# Altium schematic sheet CW313 Power Supplies.SchDoc
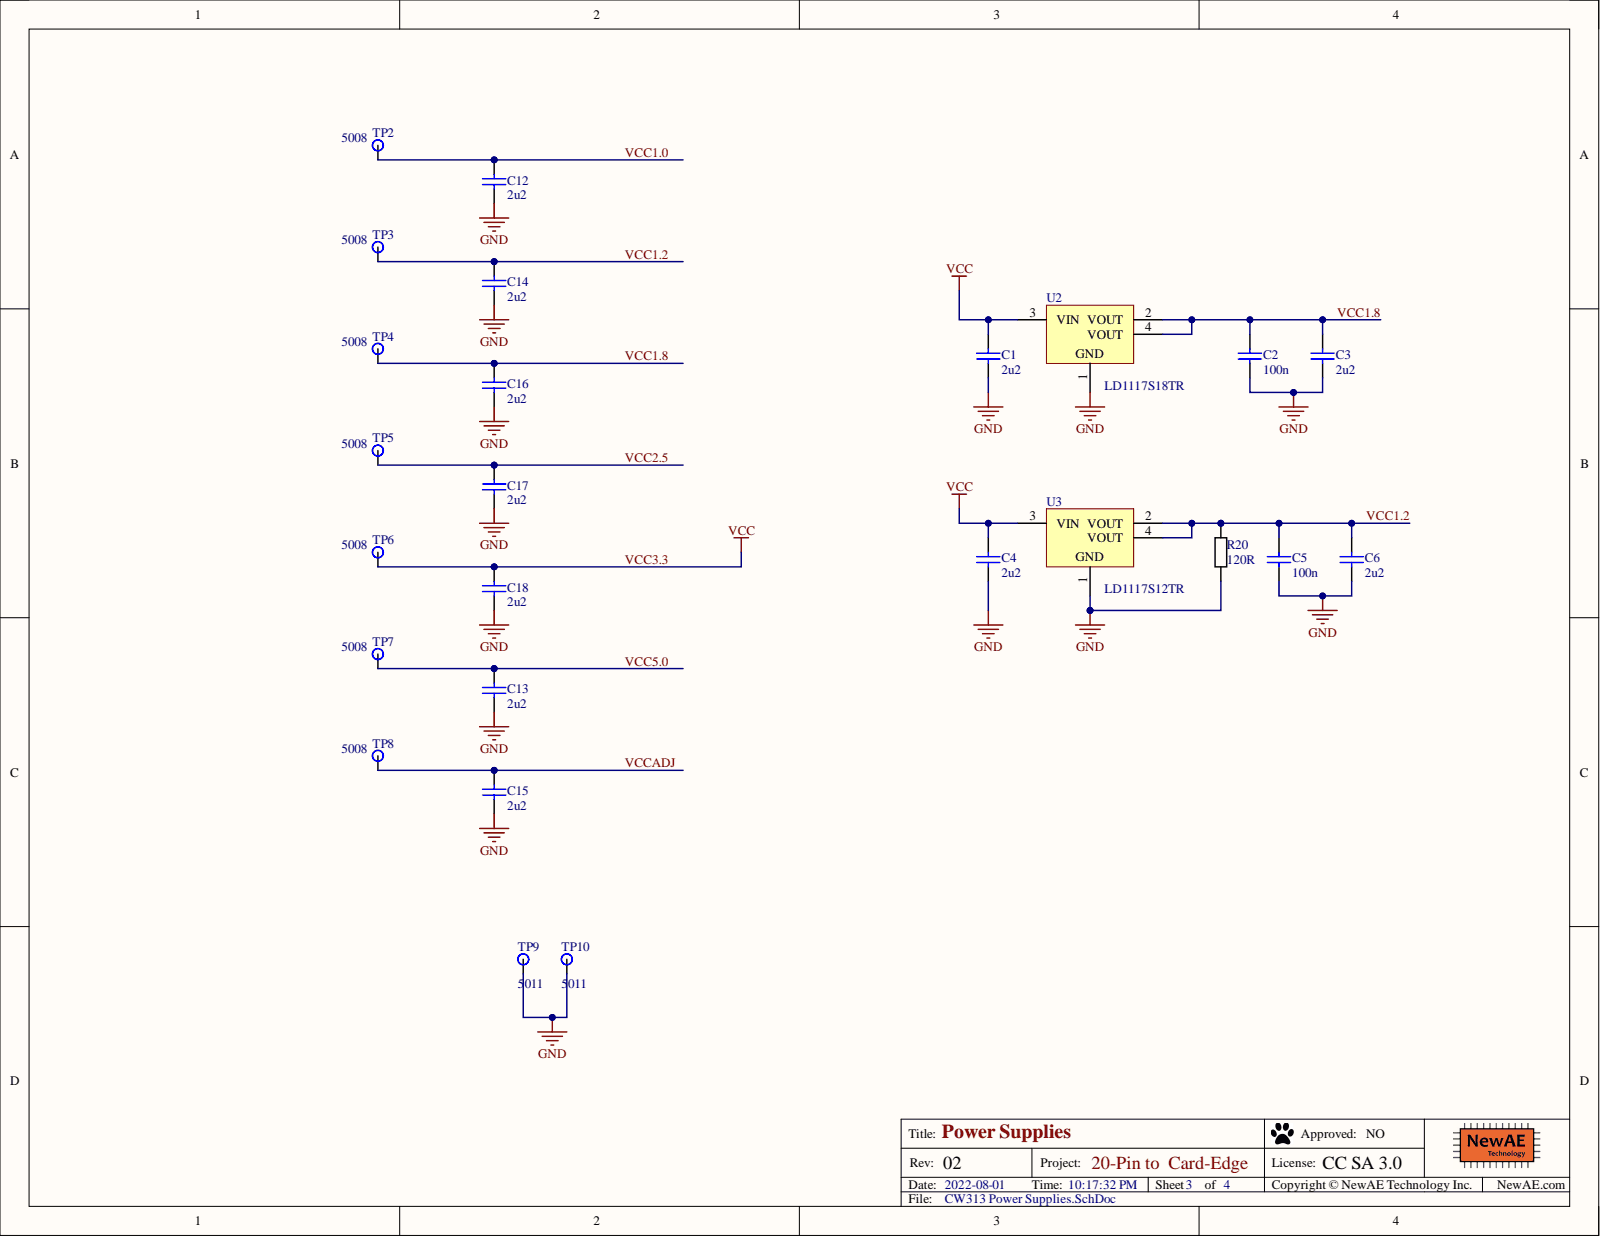
[diagram p0: CW313 Power Supplies.SchDoc]

## Parts
C1  2u2  [0603]
C2  100n  [0603]
C3  2u2  [0603]
C4  2u2  [0603]
C5  100n  [0603]
C6  2u2  [0603]
C12  2u2  [0603]
C13  2u2  [0603]
C14  2u2  [0603]
C15  2u2  [0603]
C16  2u2  [0603]
C17  2u2  [0603]
C18  2u2  [0603]
R20  120R  [0603]
TP2  5008  [KEYSTONE5008]
TP3  5008  [KEYSTONE5008]
TP4  5008  [KEYSTONE5008]
TP5  5008  [KEYSTONE5008]
TP6  5008  [KEYSTONE5008]
TP7  5008  [KEYSTONE5008]
TP8  5008  [KEYSTONE5008]
TP9  5011  [KEYSTONE5011]
TP10  5011  [KEYSTONE5011]
U2  LD1117S18TR  [SOT-223_M]
U3  LD1117S12TR  [SOT-223_M]

## Nets
GND: C1.2 C2.2 C3.2 C4.2 C5.2 C6.2 C12.2 C13.2 C14.2 C15.2 C16.2 C17.2 C18.2 R20.2 TP9.1 TP10.1 U2.1 U3.1
VCC1.0: C12.1 TP2.1
VCC1.2: C5.1 C6.1 C14.1 R20.1 TP3.1 U3.2(VOUT) U3.4(VOUT)
VCC1.8: C2.1 C3.1 C16.1 TP4.1 U2.2(VOUT) U2.4(VOUT)
VCC2.5: C17.1 TP5.1
VCC3.3: C1.1 C4.1 C18.1 TP6.1 U2.3(VIN) U3.3(VIN)
VCC5.0: C13.1 TP7.1
VCCADJ: C15.1 TP8.1

## Library data of the parts
C1 C3 C4 C6 C12-C18 = CCHIP-2u2-0603-16V: 2u2, 0603; Manufacture 1: Taiyo Yuden; Manufacture Part Number 1: EMK107BJ225KA-T; Case-Metric: 1608; Component Group: Capacitor; Database Table Name: main; Rated Voltage: 16VDC; Case-EIA: 0603; Component Kind: Standard; Component Type: Standard; Library Name: NewAE_AltiumLib.DBLib; Mounting Technology: SMT; Part Number: CCHIP-2u2-0603-16V; Pin Count: 2; Supplier 1: Digi-Key; Supplier Part Number 1: 587-1430-1-ND; Value: 2u2
C2 C5 = CCHIP-100n-0603: 100n, 0603; Manufacture 1: Kemet; Manufacture Part Number 1: C0603C104K5RACTU; Case-Metric: 1608; Component Group: Capacitor; Database Table Name: main; Rated Voltage: 50VDC; Case-EIA: 0603; Component Kind: Standard; Component Type: Standard; Library Name: NewAE_AltiumLib.DBLib; Mounting Technology: SMT; Part Number: CCHIP-100n-0603; Pin Count: 2; Supplier 1: Digi-Key; Supplier Part Number 1: 399-5089-2-ND; Value: 100n
R20 = RCHIP-120R-0603: 120R res, 0603, 1%, 1/10W; Manufacture 1: Yageo; Manufacture Part Number 1: RC0603FR-07120RL; Case-Metric: 1608; Component Group: Resistor; Database Table Name: main; Rated Power: 0.1W; Rated Voltage: 75VDC; Case-EIA: 0603; Component Kind: Standard; Component Type: Standard; Library Name: NewAE_AltiumLib.DBLib; Mounting Technology: SMT; Part Number: RCHIP-120R-0603; Pin Count: 2; Supplier 1: Digi-Key; Supplier Part Number 1: 311-120HRCT-ND; Temperature Range: -55C to 155C; Tolerance: 1%; Value: 120R
TP2-TP8 = KEYSTONE_5008: TEST POINT PC COMPACT .063"D ORG; Database Table Name: main; Component Group: Test Point; Component Kind: Standard; Component Type: Standard; Library Name: NewAE_AltiumLib.DBLib; Mounting Technology: Through-hole; Part Number: KEYSTONE_5008; Pin Count: 1; Supplier 1: Digi-Key; Supplier Part Number 1: 36-5008-ND
TP9 TP10 = KEYSTONE_5011: TEST POINT PC MULTI PURPOSE BLK; Database Table Name: main; Component Group: Test Point; Component Kind: Standard; Component Type: Standard; Library Name: NewAE_AltiumLib.DBLib; Mounting Technology: Through-hole; Part Number: KEYSTONE_5011; Pin Count: 1; Supplier 1: Digi-Key; Supplier Part Number 1: 36-5011-ND
U2 = CMP-0244-00308-1: Fixed Low Drop Positive Voltage Regulator, 1.8V, 4-Pin SOT-223, Tape and Reel; Component Kind: Standard; ComponentLink1Description: Manufacturer URL; ComponentLink1URL: http://www.st.com; ComponentLink2Description: Datasheet; ComponentLink2URL: http://www.st.com/internet/com/TECHNICAL_RESOURCES/TECHNICAL_LITERATURE/DATASHEET/CD00000544.pdf; DatasheetVersion: 1/11/2011; Library Name: Altium Content Vault; PackageDescription: 4-Pin SOT-223 Package, 6.5 x 3.5 mm Body, 2.3 mm Pitch, 7 mm Lead Span; PackageReference: SOT-223; PackageVersion: 2010; Supplier 1: Digi-Key; Supplier Part Number 1: 497-7308-1-ND
U3 = CMP-0244-00318-1: Fixed Low Drop Positive Voltage Regulator, 1.2V, 4-Pin SOT-223, Tape and Reel; Component Kind: Standard; ComponentLink1Description: Manufacturer URL; ComponentLink1URL: http://www.st.com; ComponentLink2Description: Datasheet; ComponentLink2URL: http://www.st.com/internet/com/TECHNICAL_RESOURCES/TECHNICAL_LITERATURE/DATASHEET/CD00000544.pdf; DatasheetVersion: 1/11/2011; Library Name: Altium Content Vault; PackageDescription: 4-Pin SOT-223 Package, 6.5 x 3.5 mm Body, 2.3 mm Pitch, 7 mm Lead Span; PackageReference: SOT-223; PackageVersion: 2010; Supplier 1: Digi-Key; Supplier Part Number 1: 497-4240-1-ND
